# Altium schematic sheet TB_Controller.SchDoc(TB_Controller)
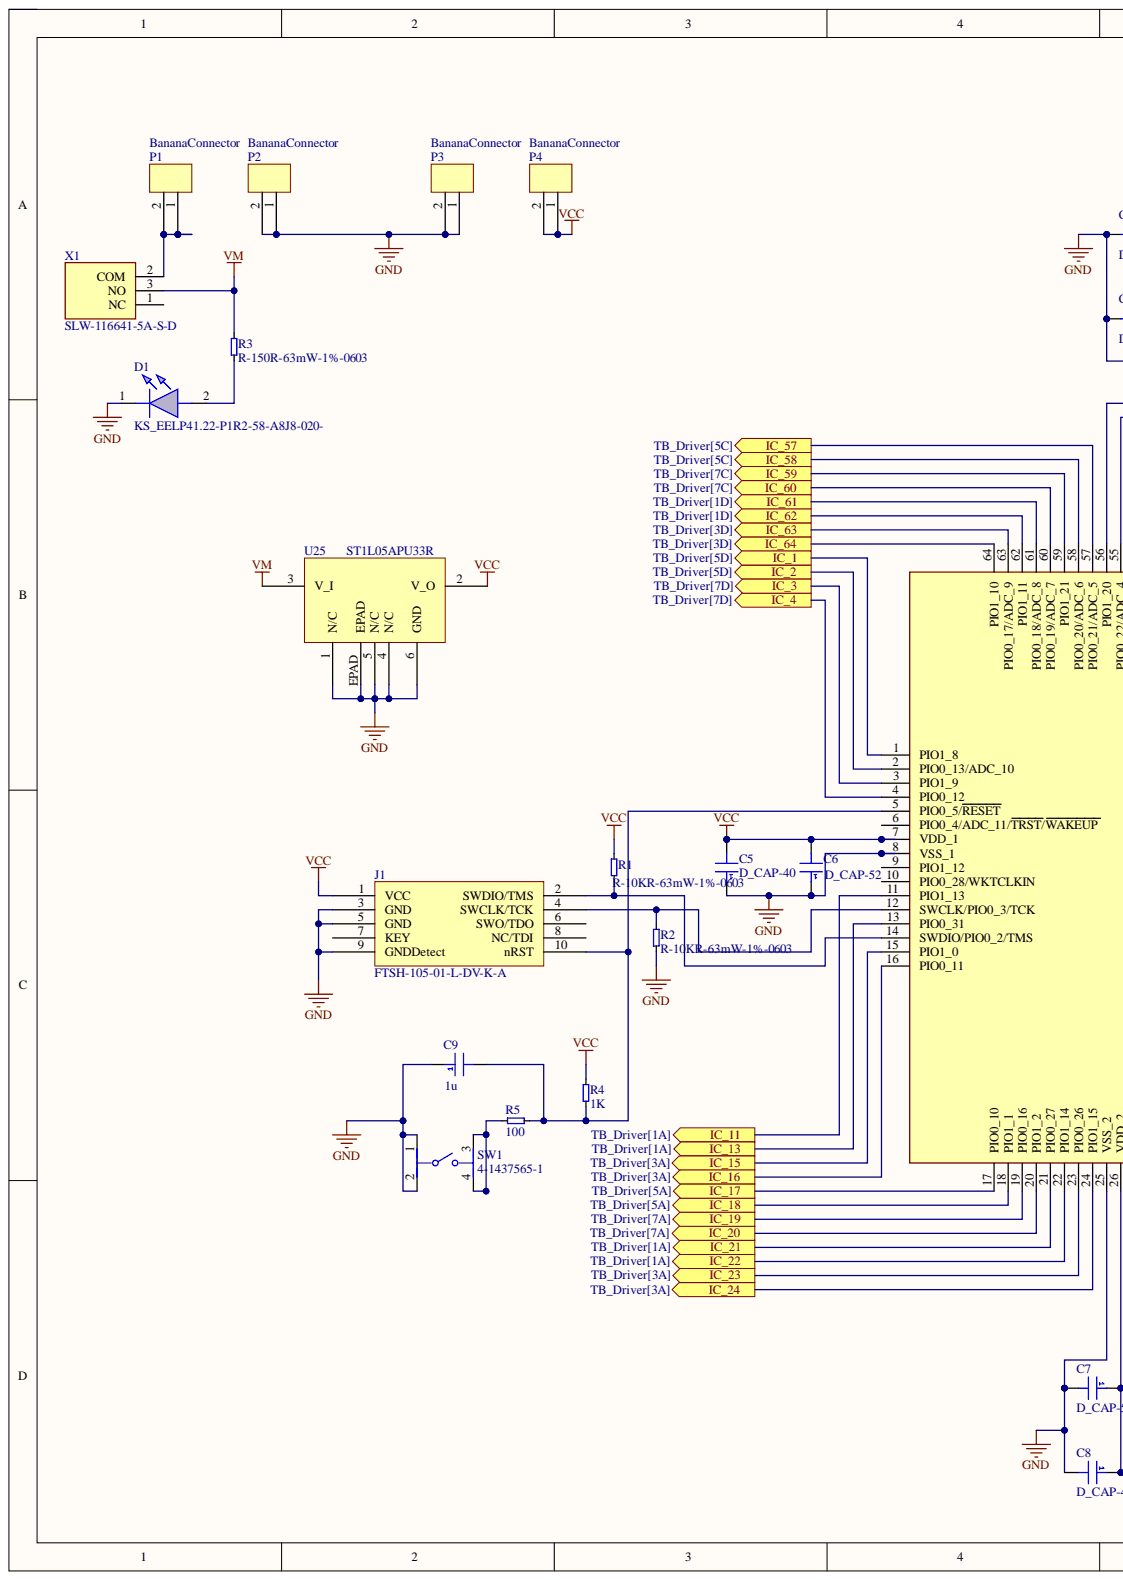
[diagram p0: TB_Controller.SchDoc, left]
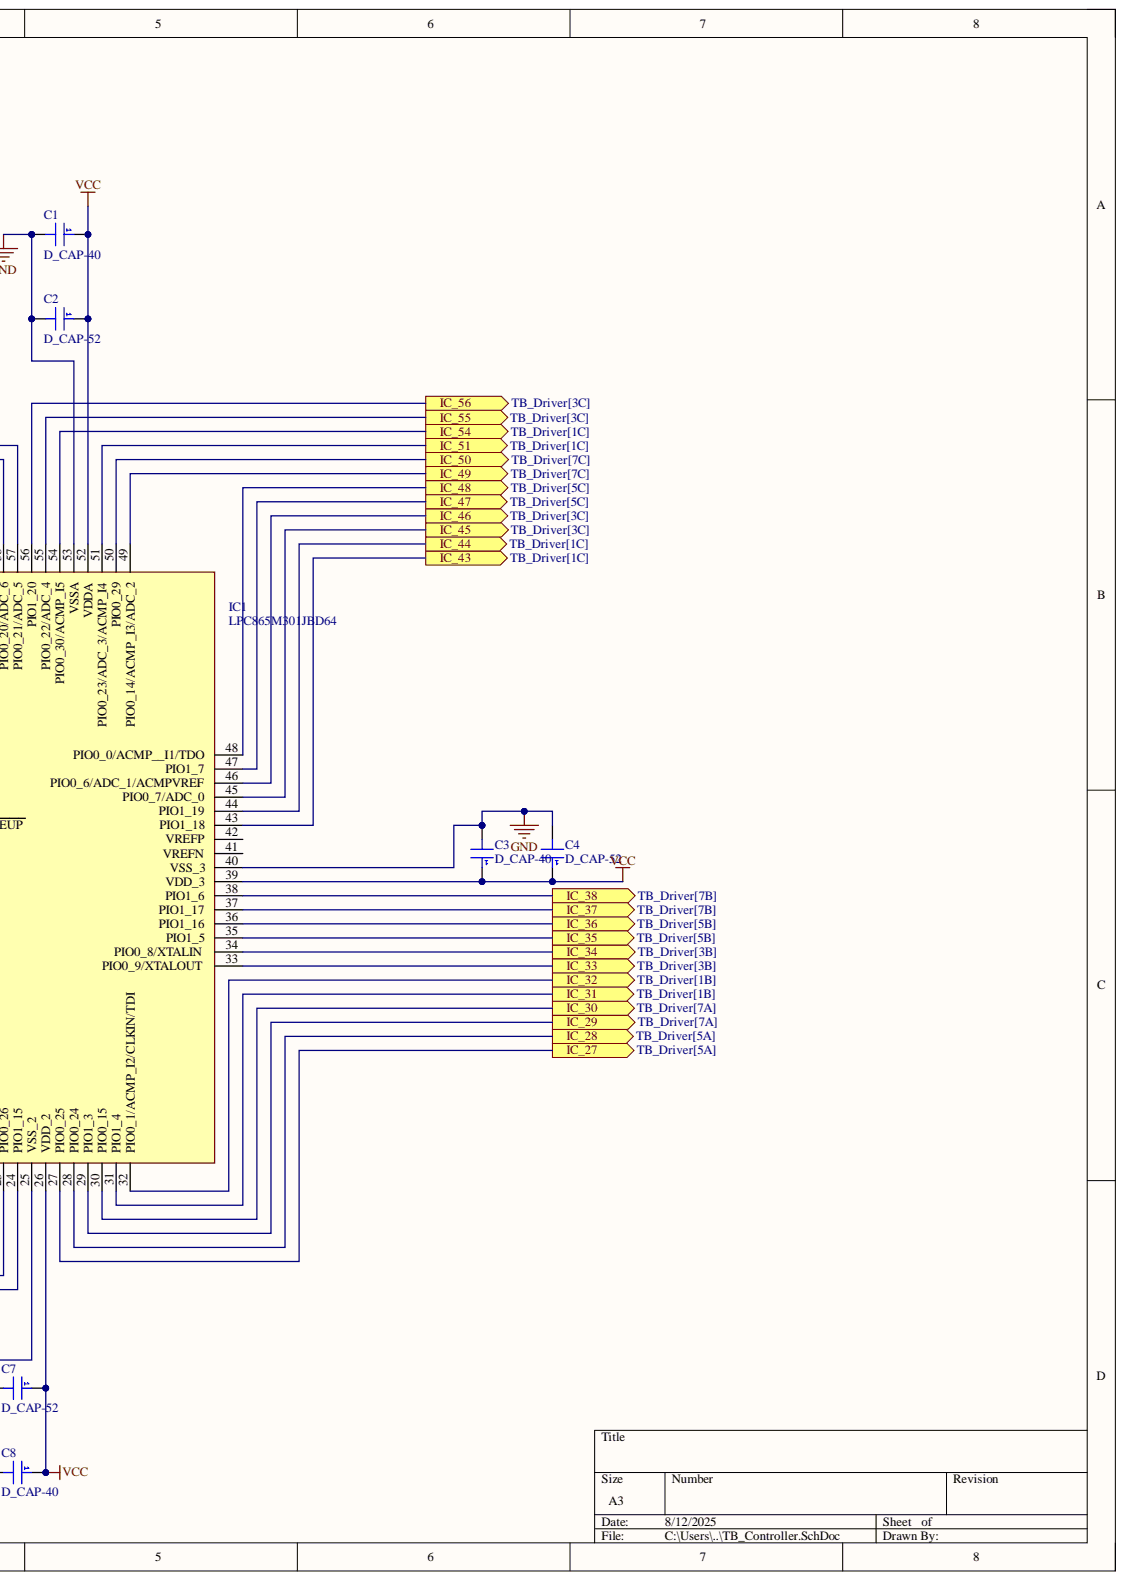
[diagram p1: TB_Controller.SchDoc, right]

## Parts
C1  D_CAP-40  [CAP_0603H0.8]
C2  D_CAP-52  [CAP_0603H0.8]
C3  D_CAP-40  [CAP_0603H0.8]
C4  D_CAP-52  [CAP_0603H0.8]
C5  D_CAP-40  [CAP_0603H0.8]
C6  D_CAP-52  [CAP_0603H0.8]
C7  D_CAP-52  [CAP_0603H0.8]
C8  D_CAP-40  [CAP_0603H0.8]
C9  1u  [CAP_0603H0.8]
D1  KS_EELP41.22-P1R2-58-A8J8-020-  [KSEELP4122P1R258A8J8020R18]
IC1  LPC865M301JBD64  [LPC865M301JBD64]
J1  FTSH-105-01-L-DV-K-A  [FTSH-105-01-L-DV-K-A]
P1  BananaConnector  [Delton Banana Plug 500 Red]
P2  BananaConnector  [Delton Banana Plug 100 Black]
P3  BananaConnector  [Delton Banana Plug 100 Black]
P4  BananaConnector  [Delton Banana Plug 500 Red]
R1  R-10KR-63mW-1%-0603  [T_RESC0603X30N]
R2  R-10KR-63mW-1%-0603  [T_RESC0603X30N]
R3  R-150R-63mW-1%-0603  [T_RESC0603X30N]
R4  1K  [T_RESC0603X30N]
R5  100  [T_RESC0603X30N]
SW1  4-1437565-1  [FP-4-1437565-1-MFG]
U25  ST1L05APU33R  [ST1L05APU33R]
X1  SLW-116641-5A-S-D  [SLW-116641-5A-S-D]

## Ports
IC_1, IC_2, IC_3, IC_4, IC_11, IC_13, IC_15, IC_16, IC_17, IC_18, IC_19, IC_20, IC_21, IC_22, IC_23, IC_24, IC_27, IC_28, IC_29, IC_30, IC_31, IC_32, IC_33, IC_34, IC_35, IC_36, IC_37, IC_38, IC_43, IC_44, IC_45, IC_46, IC_47, IC_48, IC_49, IC_50, IC_51, IC_54, IC_55, IC_56, IC_57, IC_58, IC_59, IC_60, IC_61, IC_62, IC_63, IC_64

## Nets
GND: C1.2 C2.2 C3.2 C4.2 C5.1 C6.1 C7.2 C8.2 C9.1 D1.1 IC1.8(VSS_1) IC1.25(VSS_2) IC1.40(VSS_3) IC1.53(VSSA) J1.3(GND) J1.5(GND) J1.9(GNDDetect) P2.1 P2.2 P3.1 P3.2 R2.1 SW1.1 SW1.2 U25.1(N/C) U25.4(N/C) U25.5(N/C) U25.6(GND) U25.EPAD
VCC: C1.1 C2.1 C3.1 C4.1 C5.2 C6.2 C7.1 C8.1 IC1.7(VDD_1) IC1.26(VDD_2) IC1.39(VDD_3) IC1.52(VDDA) J1.1(VCC) P4.1 P4.2 R1.2 R4.2 U25.2(V_O)
VM: R3.2 U25.3(V_I) X1.3
IC_1: IC1.1(PIO1_8) ; port IC_1
IC_2: IC1.2 ; port IC_2
IC_3: IC1.3(PIO1_9) ; port IC_3
IC_4: IC1.4 ; port IC_4
IC_11: IC1.11(PIO1_13) ; port IC_11
IC_13: IC1.13 ; port IC_13
IC_15: IC1.15(PIO1_0) ; port IC_15
IC_16: IC1.16 ; port IC_16
IC_17: IC1.17 ; port IC_17
IC_18: IC1.18(PIO1_1) ; port IC_18
IC_19: IC1.19 ; port IC_19
IC_20: IC1.20(PIO1_2) ; port IC_20
IC_21: IC1.21 ; port IC_21
IC_22: IC1.22(PIO1_14) ; port IC_22
IC_23: IC1.23 ; port IC_23
IC_24: IC1.24(PIO1_15) ; port IC_24
IC_27: IC1.27 ; port IC_27
IC_28: IC1.28 ; port IC_28
IC_29: IC1.29(PIO1_3) ; port IC_29
IC_30: IC1.30 ; port IC_30
IC_31: IC1.31(PIO1_4) ; port IC_31
IC_32: IC1.32 ; port IC_32
IC_33: IC1.33 ; port IC_33
IC_34: IC1.34 ; port IC_34
IC_35: IC1.35(PIO1_5) ; port IC_35
IC_36: IC1.36(PIO1_16) ; port IC_36
IC_37: IC1.37(PIO1_17) ; port IC_37
IC_38: IC1.38(PIO1_6) ; port IC_38
IC_43: IC1.43(PIO1_18) ; port IC_43
IC_44: IC1.44(PIO1_19) ; port IC_44
IC_45: IC1.45 ; port IC_45
IC_46: IC1.46 ; port IC_46
IC_47: IC1.47(PIO1_7) ; port IC_47
IC_48: IC1.48 ; port IC_48
IC_49: IC1.49 ; port IC_49
IC_50: IC1.50 ; port IC_50
IC_51: IC1.51 ; port IC_51
IC_54: IC1.54 ; port IC_54
IC_55: IC1.55 ; port IC_55
IC_56: IC1.56(PIO1_20) ; port IC_56
IC_57: IC1.57 ; port IC_57
IC_58: IC1.58 ; port IC_58
IC_59: IC1.59(PIO1_21) ; port IC_59
IC_60: IC1.60 ; port IC_60
IC_61: IC1.61 ; port IC_61
IC_62: IC1.62(PIO1_11) ; port IC_62
IC_63: IC1.63 ; port IC_63
IC_64: IC1.64(PIO1_10) ; port IC_64
NetC9_2: C9.2 IC1.5 J1.10(nRST) R4.1 R5.2
NetD1_2: D1.2 R3.1
NetIC1_12: IC1.12(SWCLK/PIO0_3/TCK) J1.4(SWCLK/TCK) R2.2
NetIC1_14: IC1.14(SWDIO/PIO0_2/TMS) J1.2(SWDIO/TMS) R1.1
NetP1_1: P1.1 P1.2 X1.2
NetR5_1: R5.1 SW1.3 SW1.4

Not connected: IC1 6 9(PIO1_12) 10 41(VREFN) 42(VREFP); J1 6(SWO/TDO) 7(KEY) 8(NC/TDI); X1 1

## Library data of the parts
C1 C3 C5 C8 = CMP-066-000039-1: Boite Labo 0603: Ceramic Cap, 10nF, 5%, 50V, CAP_0603H0.8; Manufacturer: Murata; ManufacturerPN: GRM1885C1H103JA01D; Designer: R.Mastrocola; Pol: NO; Tol (%): 5; UnitaryCost: 0; Component Kind: Standard; DCVoltage (V): 50V; Footprint Category: SM_CHIP; HelpURL: http://www.findchips.com/search/GRM1885C1H103JA01D; LatestRevisionDate: 19.02.2015; Part Number: D_CAP-40; Projet: Labo; Supplier1: Mouser; Supplier1PN: 81-GRM1885C1H103JA1D; Supplier2: -; Supplier2PN: -; Type: Ceramic; Value: 10nF; Library Name: A365 Rehosted HEPIA Vault
C2 C4 C6 C7 = CMP-066-000051-1: Boite Labo 0603: Ceramic Cap, 100nF, 10%, 50V, CAP_0603H0.8; Manufacturer: Murata; ManufacturerPN: GCM188L81H104KA57D; Designer: R.Mastrocola; F27: Osolète; F28: GRM188L81H104KA93D; Pol: NO; Tol (%): 10; UnitaryCost: 0.176; Component Kind: Standard; DCVoltage (V): 50V; Footprint Category: SM_CHIP; HelpURL: http://www.findchips.com/search/GCM188L81H104KA57D; LatestRevisionDate: 15.01.2020; Part Number: D_CAP-52; Projet: Labo; Supplier1: Mouser; Supplier1PN: 81-GCM188L81H104KA7D; Supplier2: -; Type: Ceramic; Value: 100nF; Library Name: A365 Rehosted HEPIA Vault
C9 = CMP-066-000063-1: Boite Labo 0603: Ceramic Cap, 1uF, 10%, 50V, CAP_0603H0.8; Manufacturer: Murata; ManufacturerPN: GRM188R61H105KAALD; Part Number: D_CAP-64; Designer: R.Mastrocola; Pol: NO; Tol (%): 10; UnitaryCost: 0.16; Component Kind: Standard; DCVoltage (V): 50V; Footprint Category: SM_CHIP; HelpURL: http://www.findchips.com/search/GRM188R61H105KAALD; LatestRevisionDate: 19.02.2015; Projet: Labo; Supplier1: Mouser; Supplier1PN: CL10A105KB8NNNC; Supplier2: Digi-Key; Supplier2PN: 1276-1860-1-ND; Type: Ceramic; Value: 1uF; Library Name: A365 Rehosted HEPIA Vault
D1 = KS_EELP41.22-P1R2-58-A8J8-020-: Standard LEDs - SMD 0603 (1608 metric); Manufacturer_Name: ams OSRAM; Manufacturer_Part_Number: KS EELP41.22-P1R2-58-A8J8-020-R18; Geometry.Height: 0.5mm; Component Kind: Standard; Datasheet Link: https://www.digikey.ph/en/products/detail/ams-osram-usa-inc/KS-EELP41-22-P1R2-58-A8J8-020-R18/24765247; Library Name: TB_Components.SchLib; Mouser Part Number: 720-P412P1R258A8J802; Mouser Price/Stock: https://www.mouser.co.uk/ProductDetail/ams-OSRAM/KS-EELP41.22-P1R2-58-A8J8-020-R18?qs=ZcfC38r4Povp3XTr6Zxf%252Bw%3D%3D
R1 R2 = CMP-003-0498-1: Resistor 10 Kohm 63mW 1% 0603; Value: 10KR; Component Kind: Standard; Component Name: R-10KR-63mW-1%-0603; Rating: 63mW; Tolerance: 1%; Library Name: A365 Rehosted HEPIA Vault; Source: Ixora.SchLib
R3 = CMP-003-0154-1: Resistor 150 ohm 63mW 1% 0603; Value: 150R; Component Kind: Standard; Component Name: R-150R-63mW-1%-0603; Rating: 63mW; Tolerance: 1%; Library Name: A365 Rehosted HEPIA Vault; Source: Ixora.SchLib
R4 = CMP-003-0419-1: Resistor 1 Kohm 63mW 5% 0603; Component Name: R-1KR-63mW-5%-0603; Component Kind: Standard; Rating: 63mW; Tolerance: 5%; Value: 1K; Library Name: A365 Rehosted HEPIA Vault; Source: Ixora.SchLib
R5 = CMP-003-0496-1: Resistor 100 ohm 63mW 5% 0603; Component Name: R-100R-63mW-5%-0603; Value: 100R; Component Kind: Standard; Rating: 63mW; Tolerance: 5%; Library Name: A365 Rehosted HEPIA Vault; Source: Ixora.SchLib
SW1 = CMP-03407-000003-1: SWITCH TACTILE SPST-NO 0.05A 24V; Manufacturer: TE Connectivity; Ciiva Ids: 2672442; Contact Current Rating: 50mA; Contact Resistance: 100mΩ; Electromechanical Life: 100000Cycles; Import Ts: 1521848451; Operating Force: 160gf; Standoff Height: 0.05mm; Automotive: No; Category: Switch; Component Kind: Standard; ComponentLink2Description: Datasheet; ComponentLink2URL: https://www.te.com/commerce/DocumentDelivery/DDEController?Action=showdoc&DocId=Customer+Drawing%7F2-1437565-7%7FV%7Fpdf%7FEnglish%7FENG_CD_2-1437565-7_V.pdf%7F4-1437565-1; Device Class L1: Electromechanical; Device Class L2: Switches; Device Class L3: Tactile Switches; Digikey Description: SWITCH TACTILE SPST-NO 0.05A 24V; Height: 5.38mm; Lead Free: Yes; Library Name: Altium Content Vault; Manufacturer Part Number: 4-1437565-1; Mount: Surface Mount; Mouser Description: Switch Tactile OFF (ON) SPST Round Button Gull Wing 0.05A 12VDC 1.57N SMD Loose Piece; Octopart: https://octopart.com/fsm4jsma-te+connectivity-55488004; Package: 0; Release Date: 1503379960; RoHS: TRUE; Temperature: -35°C to +85°C; Temperature Range High: +85°C; Temperature Range Low: -35°C; Throw Configuration: SPST-NO; Voltage Rating Dc: 24V
U25 = ST1L05APU33R: DFN6 (3x3 mm) Input -0.3 to 7V and output 3.3V at max 1.3A; Component Kind: Standard; Library Name: TB_Components.SchLib
X1 = SLW-116641-5A-S-D: 11.6 x 6 x 4.1 mm, 5 mm Raised Slide Actuator, Through Hole, Slide Switch; Component Kind: Standard; Library Name: TB_Components.SchLib
IC1 = LPC865M301JBD64: Component Kind: Standard; Library Name: TB_Components.SchLib
J1 = FTSH-105-01-L-DV-K-A: Component Kind: Standard; Library Name: TB_Components.SchLib
P1-P4 = BananaConnector: Component Kind: Standard; Library Name: TB_Components.SchLib
